# Altium schematic sheet SCH_pmTPS_xc9572.SchDoc
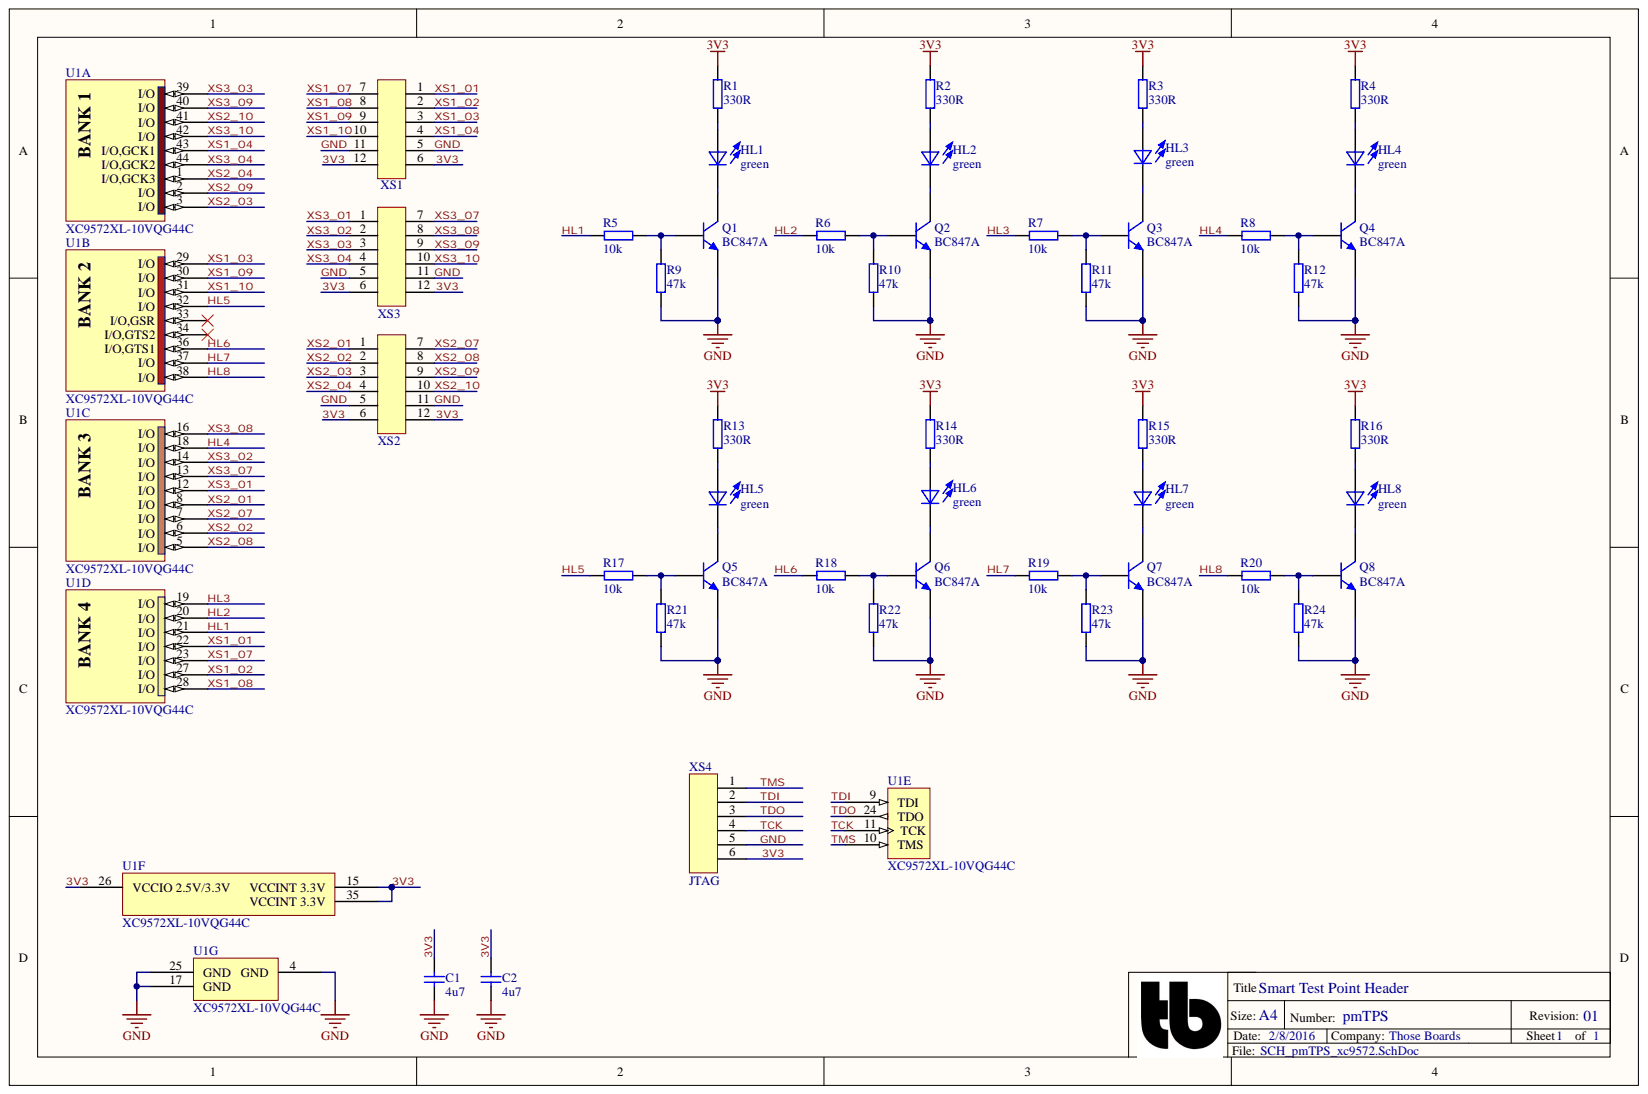
[diagram p0: SCH_pmTPS_xc9572.SchDoc]

## Parts
C1  4u7  [0805_capacitor]
C2  4u7  [0805_capacitor]
HL1  green  [0805_LED]
HL2  green  [0805_LED]
HL3  green  [0805_LED]
HL4  green  [0805_LED]
HL5  green  [0805_LED]
HL6  green  [0805_LED]
HL7  green  [0805_LED]
HL8  green  [0805_LED]
Q1  BC847A  [SOT-23]
Q2  BC847A  [SOT-23]
Q3  BC847A  [SOT-23]
Q4  BC847A  [SOT-23]
Q5  BC847A  [SOT-23]
Q6  BC847A  [SOT-23]
Q7  BC847A  [SOT-23]
Q8  BC847A  [SOT-23]
R1  330R  [0603_RESISTOR]
R2  330R  [0603_RESISTOR]
R3  330R  [0603_RESISTOR]
R4  330R  [0603_RESISTOR]
R5  10k  [0603_RESISTOR]
R6  10k  [0603_RESISTOR]
R7  10k  [0603_RESISTOR]
R8  10k  [0603_RESISTOR]
R9  47k  [0603_RESISTOR]
R10  47k  [0603_RESISTOR]
R11  47k  [0603_RESISTOR]
R12  47k  [0603_RESISTOR]
R13  330R  [0603_RESISTOR]
R14  330R  [0603_RESISTOR]
R15  330R  [0603_RESISTOR]
R16  330R  [0603_RESISTOR]
R17  10k  [0603_RESISTOR]
R18  10k  [0603_RESISTOR]
R19  10k  [0603_RESISTOR]
R20  10k  [0603_RESISTOR]
R21  47k  [0603_RESISTOR]
R22  47k  [0603_RESISTOR]
R23  47k  [0603_RESISTOR]
R24  47k  [0603_RESISTOR]
U1  XC9572XL-10VQG44C  [VQG44_N]  (parts A,B,C,D,E,F,G)
XS1  DG2_conn_pmod  [IDC2X6M_PMOD]  (value not drawn)
XS2  DG2_connector_horizontal_f  [CONN_2DG_H_F]  (value not drawn)
XS3  DG2_connector_vertical  [Conn_2DG_vertical]  (value not drawn)
XS4  JTAG  [Conn_6p]

## Nets
3V3: C1.1 C2.1 R1.2 R2.2 R3.2 R4.2 R13.2 R14.2 R15.2 R16.2 U1.15(VCCINT_3.3V) U1.26(VCCIO_2.5V/3.3V) U1.35(VCCINT_3.3V) XS1.6 XS1.12 XS2.6 XS2.12 XS3.6 XS3.12 XS4.6
GND: C1.2 C2.2 Q1.2 Q2.2 Q3.2 Q4.2 Q5.2 Q6.2 Q7.2 Q8.2 R9.1 R10.1 R11.1 R12.1 R21.1 R22.1 R23.1 R24.1 U1.4(GND) U1.17(GND) U1.25(GND) XS1.5 XS1.11 XS2.5 XS2.11 XS3.5 XS3.11 XS4.5
HL1: R5.2 U1.21(I/O)
HL2: R6.2 U1.20(I/O)
HL3: R7.2 U1.19(I/O)
HL4: R8.2 U1.18(I/O)
HL5: R17.2 U1.32(I/O)
HL6: R18.2 U1.36(I/O,GTS1)
HL7: R19.2 U1.37(I/O)
HL8: R20.2 U1.38(I/O)
TCK: U1.11(TCK) XS4.4
TDI: U1.9(TDI) XS4.2
TDO: U1.24(TDO) XS4.3
TMS: U1.10(TMS) XS4.1
XS1_01: U1.22(I/O) XS1.1
XS1_02: U1.27(I/O) XS1.2
XS1_03: U1.29(I/O) XS1.3
XS1_04: U1.43(I/O,GCK1) XS1.4
XS1_07: U1.23(I/O) XS1.7
XS1_08: U1.28(I/O) XS1.8
XS1_09: U1.30(I/O) XS1.9
XS1_10: U1.31(I/O) XS1.10
XS2_01: U1.8(I/O) XS2.1
XS2_02: U1.6(I/O) XS2.2
XS2_03: U1.3(I/O) XS2.3
XS2_04: U1.1(I/O,GCK3) XS2.4
XS2_07: U1.7(I/O) XS2.7
XS2_08: U1.5(I/O) XS2.8
XS2_09: U1.2(I/O) XS2.9
XS2_10: U1.41(I/O) XS2.10
XS3_01: U1.12(I/O) XS3.1
XS3_02: U1.14(I/O) XS3.2
XS3_03: U1.39(I/O) XS3.3
XS3_04: U1.44(I/O,GCK2) XS3.4
XS3_07: U1.13(I/O) XS3.7
XS3_08: U1.16(I/O) XS3.8
XS3_09: U1.40(I/O) XS3.9
XS3_10: U1.42(I/O) XS3.10
NetHL1_1: HL1.1 R1.1
NetHL1_2: HL1.2 Q1.3
NetHL2_1: HL2.1 R2.1
NetHL2_2: HL2.2 Q2.3
NetHL3_1: HL3.1 R3.1
NetHL3_2: HL3.2 Q3.3
NetHL4_1: HL4.1 R4.1
NetHL4_2: HL4.2 Q4.3
NetHL5_1: HL5.1 R13.1
NetHL5_2: HL5.2 Q5.3
NetHL6_1: HL6.1 R14.1
NetHL6_2: HL6.2 Q6.3
NetHL7_1: HL7.1 R15.1
NetHL7_2: HL7.2 Q7.3
NetHL8_1: HL8.1 R16.1
NetHL8_2: HL8.2 Q8.3
NetQ1_1: Q1.1 R5.1 R9.2
NetQ2_1: Q2.1 R6.1 R10.2
NetQ3_1: Q3.1 R7.1 R11.2
NetQ4_1: Q4.1 R8.1 R12.2
NetQ5_1: Q5.1 R17.1 R21.2
NetQ6_1: Q6.1 R18.1 R22.2
NetQ7_1: Q7.1 R19.1 R23.2
NetQ8_1: Q8.1 R20.1 R24.2

Not connected: U1 33(I/O,GSR) 34(I/O,GTS2)

## Library data of the parts
C1 C2 = cap_0805: Component Kind: Standard; Library Name: 1st.SchLib
HL1-HL8 = LED: Component Kind: Standard; Library Name: 1st.SchLib
Q1-Q8 = BC847A: NPN General-purpose Transistor; Component Kind: Standard; ComponentLink1Description: Manufacturer Link; ComponentLink1URL: http://www.semiconductors.philips.com/; ComponentLink2Description: Datasheet; ComponentLink2URL: http://www.semiconductors.philips.com/acrobat/datasheets/BC846_BC847_BC848_5.pdf; DatasheetVersion: 4-Feb-2002; LatestRevisionDate: 12-Dec-2006; LatestRevisionNote: IPC-7351 Footprint Added.; Library Name: 1st.SchLib; PackageDescription: 3-Pin SOT-23 Package 0.95 mm Pitch, 2.3 mm Lead Span; PackageReference: SOT23; PackageVersion: May-1999; Published: 17-Sep-2003; Signal Integrity: BC847A; Simulation: QBC847A; Publisher: Altium Limited
R1-R24 = RES_0603: Component Kind: Standard; Library Name: 1st.SchLib
U1 = XC9572XL-10VQG44C: FastFLASH XC900XL, 3.3V High-Performance CPLD with 72 Macrocells, 44-Pin VQFP, Commercial Grade, Pb-Free; Code_JEDEC: MS-026-ACB; Component Kind: Standard; ComponentLink1Description: Manufacturer Link; ComponentLink1URL: http://www.xilinx.com/products/silicon_solutions/cplds/xc9500_series/xc9500xl/index.htm; ComponentLink2Description: Datasheet; ComponentLink2URL: http://direct.xilinx.com/bvdocs/publications/ds057.pdf; DatasheetVersion: ver1.9 Mar-2006; Library Name: Xilinx PLD XC9500XL.IntLib; PackageDescription: 44-Pin Very Thin Quad Flat Pack (VQG44) - Pb-Free; PackageReference: VQG44; PackageVersion: ver1.2, Jun-2004; PCB3D: XC9572XL-VQ44; Published: 8-Sep-2006; Signal Integrity: XC9572XL_VQ44; Publisher: Altium Limited
XS4 = conn_6p: Component Kind: Standard; Library Name: 1st.SchLib
XS1 = DG2_conn_pmod: Component Kind: Standard; Library Name: 1st.SchLib
XS2 = DG2_connector_horizontal_f: Component Kind: Standard; Library Name: 1st.SchLib
XS3 = DG2_connector_vertical: Component Kind: Standard; Library Name: 1st.SchLib
